# Altium schematic sheet sch4.SchDoc
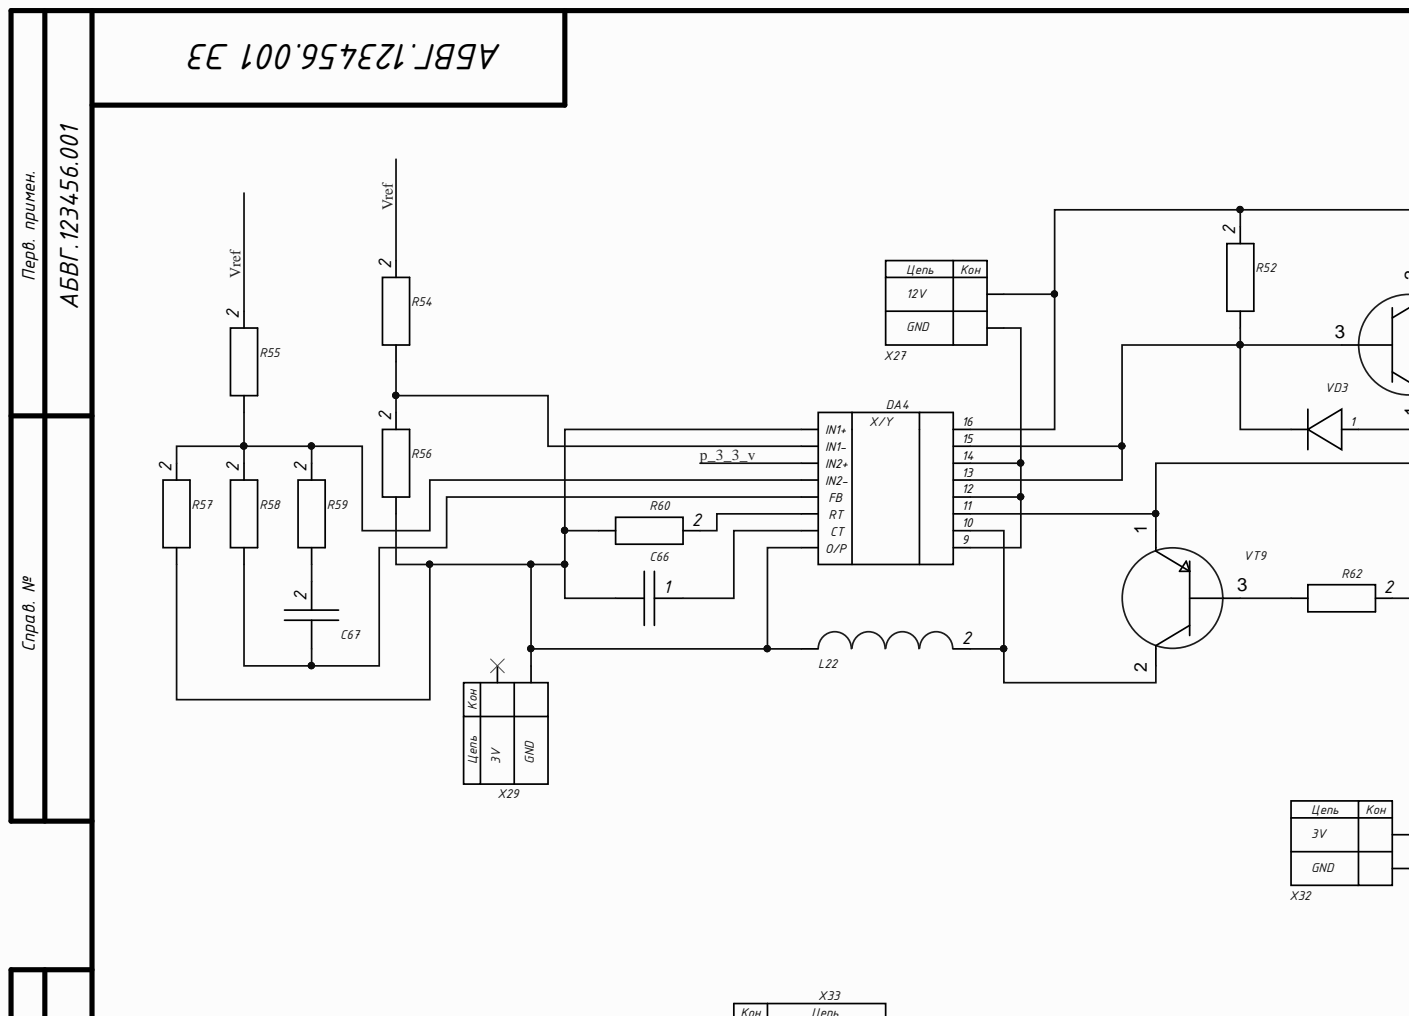
[diagram p0: sch4.SchDoc, top left]
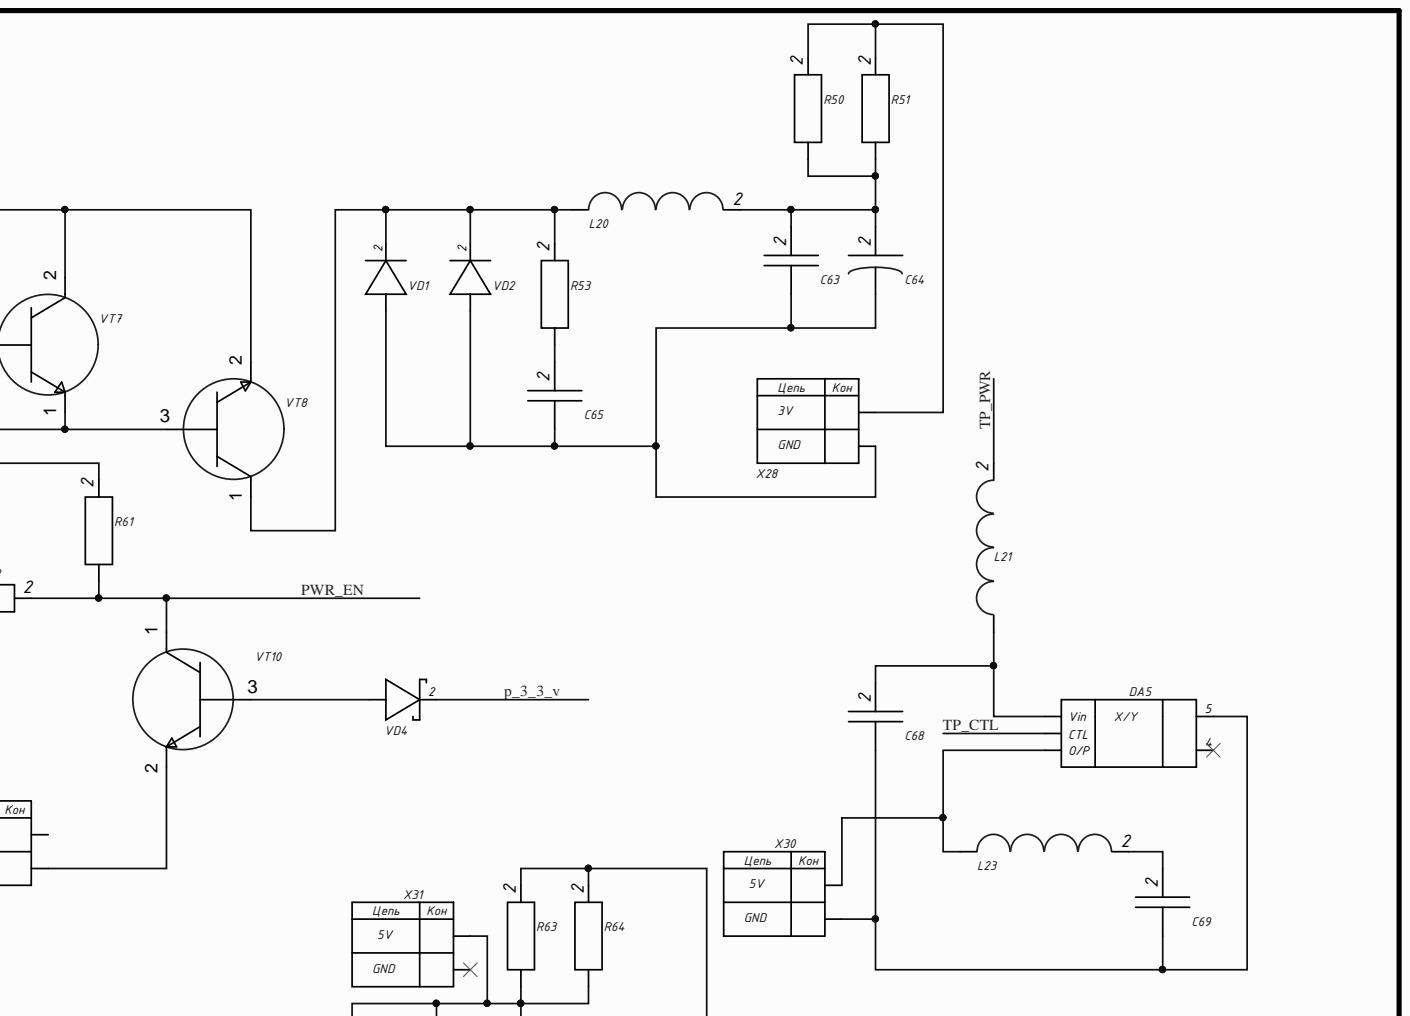
[diagram p1: sch4.SchDoc, top right]
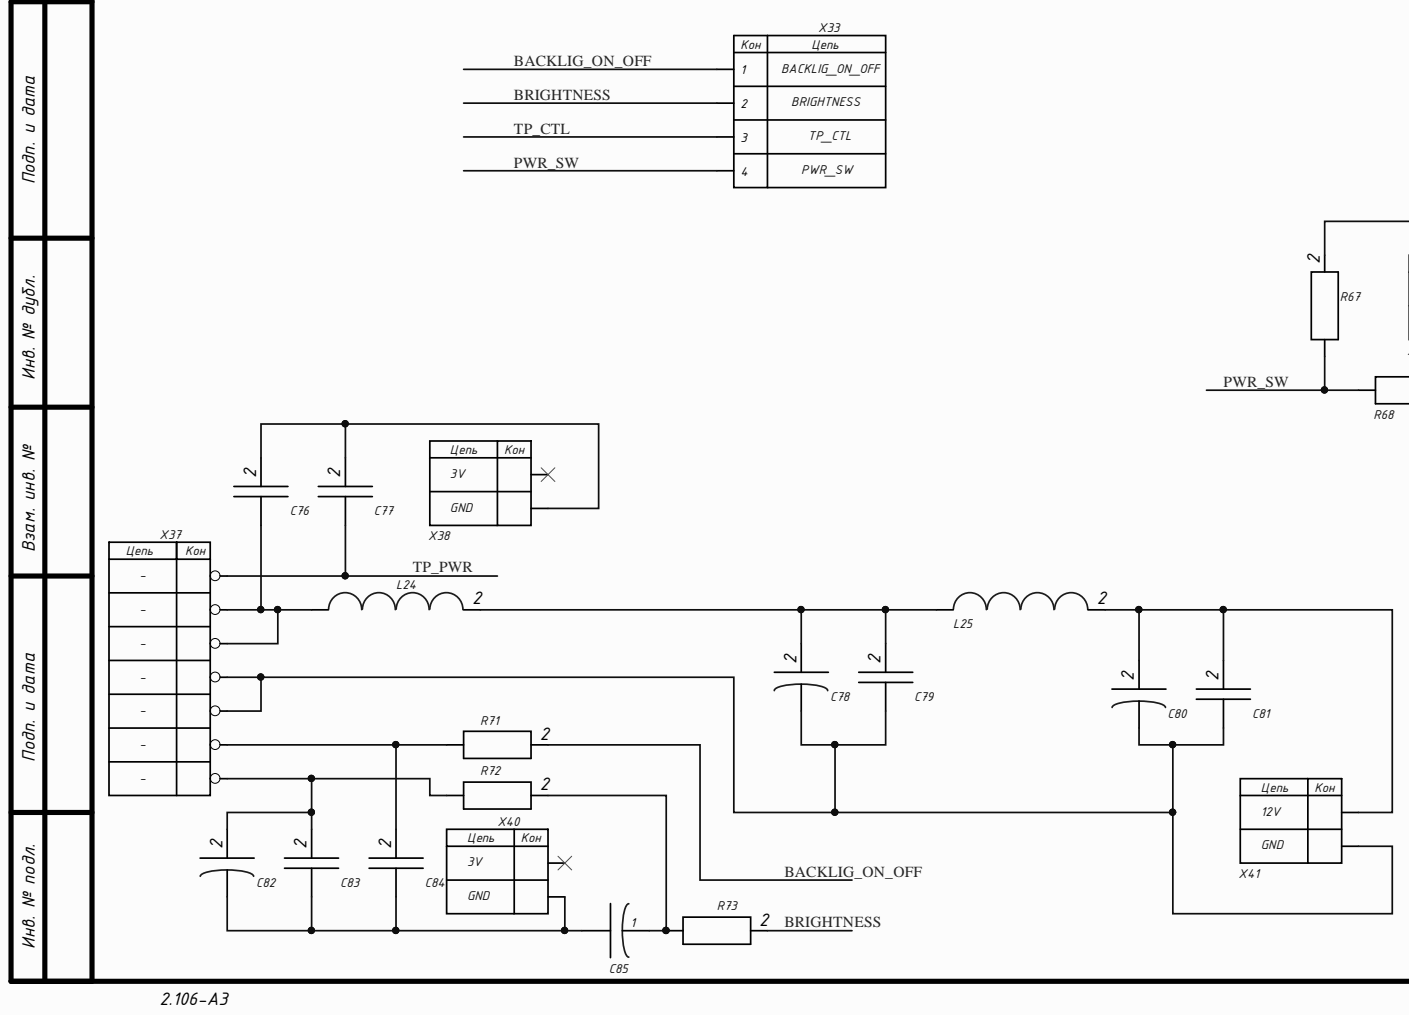
[diagram p2: sch4.SchDoc, bottom left]
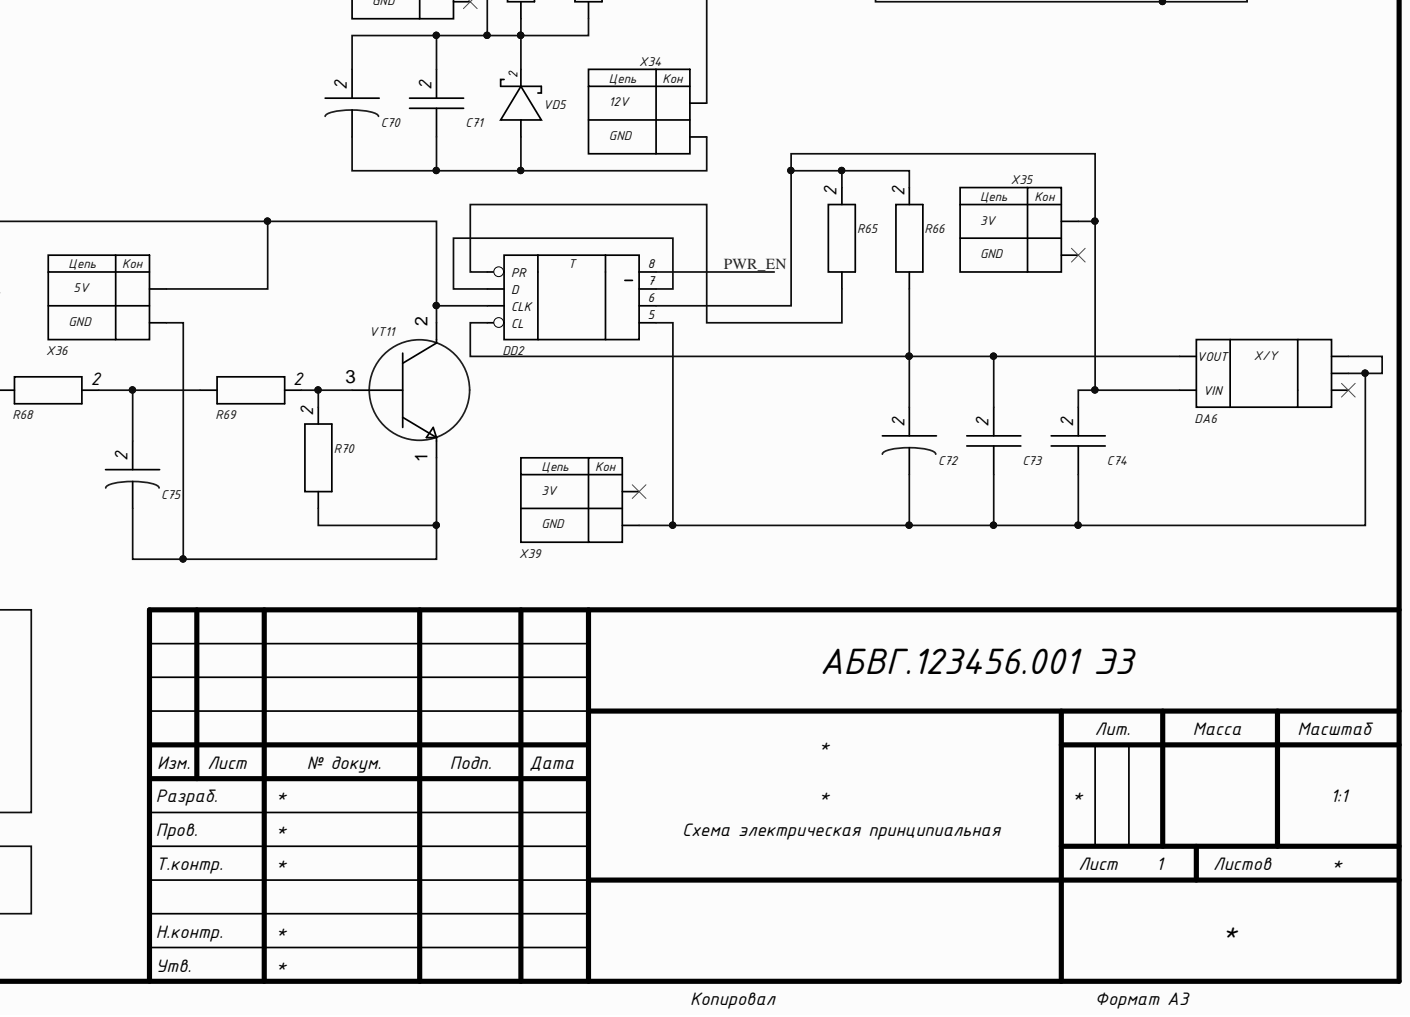
[diagram p3: sch4.SchDoc, bottom right]

## Parts
C63  (no value)  [Capacitor 0805]
C64  (no value)  [Capacitor 0805]
C65  (no value)  [Capacitor 0805]
C66  (no value)  [Capacitor 0805]
C67  (no value)  [Capacitor 0805]
C68  (no value)  [Capacitor 0805]
C69  (no value)  [Capacitor 0805]
C70  (no value)  [Capacitor 0805]
C71  (no value)  [Capacitor 0805]
C72  (no value)  [Capacitor 0805]
C73  (no value)  [Capacitor 0805]
C74  (no value)  [Capacitor 0805]
C75  (no value)  [Capacitor 0805]
C76  (no value)  [Capacitor 0805]
C77  (no value)  [Capacitor 0805]
C78  (no value)  [Capacitor 0805]
C79  (no value)  [Capacitor 0805]
C80  (no value)  [Capacitor 0805]
C81  (no value)  [Capacitor 0805]
C82  (no value)  [Capacitor 0805]
C83  (no value)  [Capacitor 0805]
C84  (no value)  [Capacitor 0805]
C85  (no value)  [Capacitor 0805]
DA4  (no value)  [AP494A]
DA5  (no value)  [PQ05]
DA6  (no value)  [IC103]
DD2  (no value)  [D FF]
L20  (no value)  [Inductor 2520]
L21  (no value)  [Inductor 2520]
L22  (no value)  [Inductor 2520]
L23  (no value)  [Inductor 2520]
L24  (no value)  [Inductor 2520]
L25  (no value)  [Inductor 2520]
R50  (no value)  [Resistor 1206]
R51  (no value)  [Resistor 1206]
R52  (no value)  [Resistor 1206]
R53  (no value)  [Resistor 1206]
R54  (no value)  [Resistor 1206]
R55  (no value)  [Resistor 1206]
R56  (no value)  [Resistor 1206]
R57  (no value)  [Resistor 1206]
R58  (no value)  [Resistor 1206]
R59  (no value)  [Resistor 1206]
R60  (no value)  [Resistor 1206]
R61  (no value)  [Resistor 1206]
R62  (no value)  [Resistor 1206]
R63  (no value)  [Resistor 1206]
R64  (no value)  [Resistor 1206]
R65  (no value)  [Resistor 1206]
R66  (no value)  [Resistor 1206]
R67  (no value)  [Resistor 1206]
R68  (no value)  [Resistor 1206]
R69  (no value)  [Resistor 1206]
R70  (no value)  [Resistor 1206]
R71  (no value)  [Resistor 1206]
R72  (no value)  [Resistor 1206]
R73  (no value)  [Resistor 1206]
VD1  (no value)  [Diode]
VD2  (no value)  [Diode]
VD3  (no value)  [Diode]
VD4  (no value)  [Diode]
VD5  (no value)  [Diode]
VT7  (no value)  [Transistor 1]
VT8  (no value)  [Transistor 1]
VT9  (no value)  [Transistor 1]
VT10  (no value)  [Transistor 1]
VT11  (no value)  [Transistor 1]
X27  (no value)  [VCC-GND]
X28  (no value)  [VCC-GND]
X29  (no value)  [VCC-GND]
X30  (no value)  [VCC-GND]
X31  (no value)  [VCC-GND]
X32  (no value)  [VCC-GND]
X33  (no value)  [Connector]
X34  (no value)  [VCC-GND]
X35  (no value)  [VCC-GND]
X36  (no value)  [VCC-GND]
X37  (no value)  [J601]
X38  (no value)  [VCC-GND]
X39  (no value)  [VCC-GND]
X40  (no value)  [VCC-GND]
X41  (no value)  [VCC-GND]

## Nets
BACKLIG_ON_OFF: R71.2 X33.1
BRIGHTNESS: R73.2 X33.2
p_3_3_v: DA4.3(IN2+) VD4.2
PWR_EN: DD2.8 R61.1 R62.2 VT10.1
PWR_SW: R67.1 R68.1 X33.4
TP_CTL: DA5.2(CTL) X33.3
TP_PWR: C77.1 L21.2 X37.7
Vref: R54.2 R55.2
NetC63_1: C63.1 C64.1 C65.1 VD1.1 VD2.1 X28.2
NetC63_2: C63.2 C64.2 L20.2 R50.1 R51.1
NetC65_2: C65.2 R53.1
NetC66_1: C66.1 DA4.7(CT)
NetC66_2: C66.2 DA4.1(IN1+) DA4.8(O/P) L22.1 R56.1 R57.1 R60.1 X29.2
NetC67_1: C67.1 DA4.5(FB) R58.1
NetC67_2: C67.2 R59.1
NetC68_1: C68.1 C69.1 DA5.5 X30.2
NetC68_2: C68.2 DA5.1(Vin) L21.1
NetC69_2: C69.2 L23.2
NetC70_1: C70.1 C71.1 VD5.1 X34.2
NetC70_2: C70.2 C71.2 R63.1 R64.1 VD5.2 X31.1
NetC72_1: C72.1 C73.1 C74.1 DA6.1 DA6.2 DD2.5 X39.2
NetC72_2: C72.2 C73.2 DA6.4 DD2.4(CL) R66.1
NetC74_2: C74.2 DA6.5(VIN) DD2.6 R65.2 R66.2 X35.1
NetC75_1: C75.1 R70.1 VT11.1 X36.2
NetC75_2: C75.2 R68.2 R69.1
NetC76_1: C76.1 L24.1 X37.5 X37.6
NetC76_2: C76.2 C77.2 X38.2
NetC78_1: C78.1 C79.1 C80.1 C81.1 X37.3 X37.4 X41.2
NetC78_2: C78.2 C79.2 L24.2 L25.1
NetC80_2: C80.2 C81.2 L25.2 X41.1
NetC82_1: C82.1 C83.1 C84.1 C85.2 X40.2
NetC82_2: C82.2 C83.2 R72.1 X37.1
NetC84_2: C84.2 R71.1 X37.2
NetC85_1: C85.1 R72.2 R73.1
NetDA4_2: DA4.2(IN1-) R54.1 R56.2
NetDA4_4: DA4.4(IN2-) R55.1 R57.2 R58.2 R59.2
NetDA4_6: DA4.6(RT) R60.2
NetDA4_9: DA4.9 DA4.12 DA4.14 X27.2
NetDA4_10: DA4.10 L22.2 VT9.2
NetDA4_11: DA4.11 R61.2 VT9.1
NetDA4_13: DA4.13 DA4.15 R52.1 VD3.2 VT7.3
NetDA4_16: DA4.16 R52.2 VT7.2 VT8.2 X27.1
NetDA5_3: DA5.3(O/P) L23.1 X30.1
NetDD2_1: DD2.1(PR) R65.1
NetDD2_2: DD2.2(D) DD2.7
NetDD2_3: DD2.3(CLK) R67.2 VT11.2 X36.1
NetL20_1: L20.1 R53.2 VD1.2 VD2.2 VT8.1
NetR50_2: R50.2 R51.2 X28.1
NetR62_1: R62.1 VT9.3
NetR63_2: R63.2 R64.2 X34.1
NetR69_2: R69.2 R70.2 VT11.3
NetVD3_1: VD3.1 VT7.1 VT8.3
NetVD4_1: VD4.1 VT10.3
NetVT10_2: VT10.2 X32.2

Not connected: DA5 4; DA6 3; X29 1; X31 2; X32 1; X35 2; X38 1; X39 1; X40 1

## Library data of the parts
C63 C65-C69 C71 C73 C74 C76 C77 C79 C81 C83 C84 = Capacitor: Component Kind: Standard; Library Name: k7shared.IntLib
C64 C70 C72 C75 C78 C80 C82 C85 = Capacitor Duga: Component Kind: Standard; Library Name: k7shared.IntLib
DA4 = AP494A: Component Kind: Standard; Library Name: k7sch4.IntLib
DA5 = PQ05: Component Kind: Standard; Library Name: k7sch4.IntLib
DA6 = IC103: Component Kind: Standard; Library Name: k7sch3.IntLib
DD2 = 74F74: Component Kind: Standard; Library Name: k7sch4.IntLib
L20-L25 = Inductor: Component Kind: Standard; Library Name: k7shared.IntLib
R50-R73 = Resistor: Component Kind: Standard; Library Name: k7shared.IntLib
VD1-VD3 = Diode 1: Component Kind: Standard; Library Name: k7shared.IntLib
VD4 VD5 = Diode 2: Component Kind: Standard; Library Name: k7shared.IntLib
VT7 VT11 = Transistor 1: Component Kind: Standard; Library Name: k7shared.IntLib
VT8 VT10 = Transistor 2_1: Component Kind: Standard; Library Name: k7shared.IntLib
VT9 = Transistor 1_1: Component Kind: Standard; Library Name: k7shared.IntLib
X27 X34 X41 = 12V-GND: Component Kind: Standard; Library Name: k7shared.IntLib
X28 X29 X32 X35 X38-X40 = VCC-GND R: Component Kind: Standard; Library Name: k7shared.IntLib
X30 X31 X36 = 5V-GND: Component Kind: Standard; Library Name: k7shared.IntLib
X33 = Connector: Component Kind: Standard; Library Name: k7sch4.IntLib
X37 = J601: Component Kind: Standard; Library Name: k7sch4.IntLib
